# Altium schematic sheet Schem, Transadmittance Amp_rev_B.SchDoc
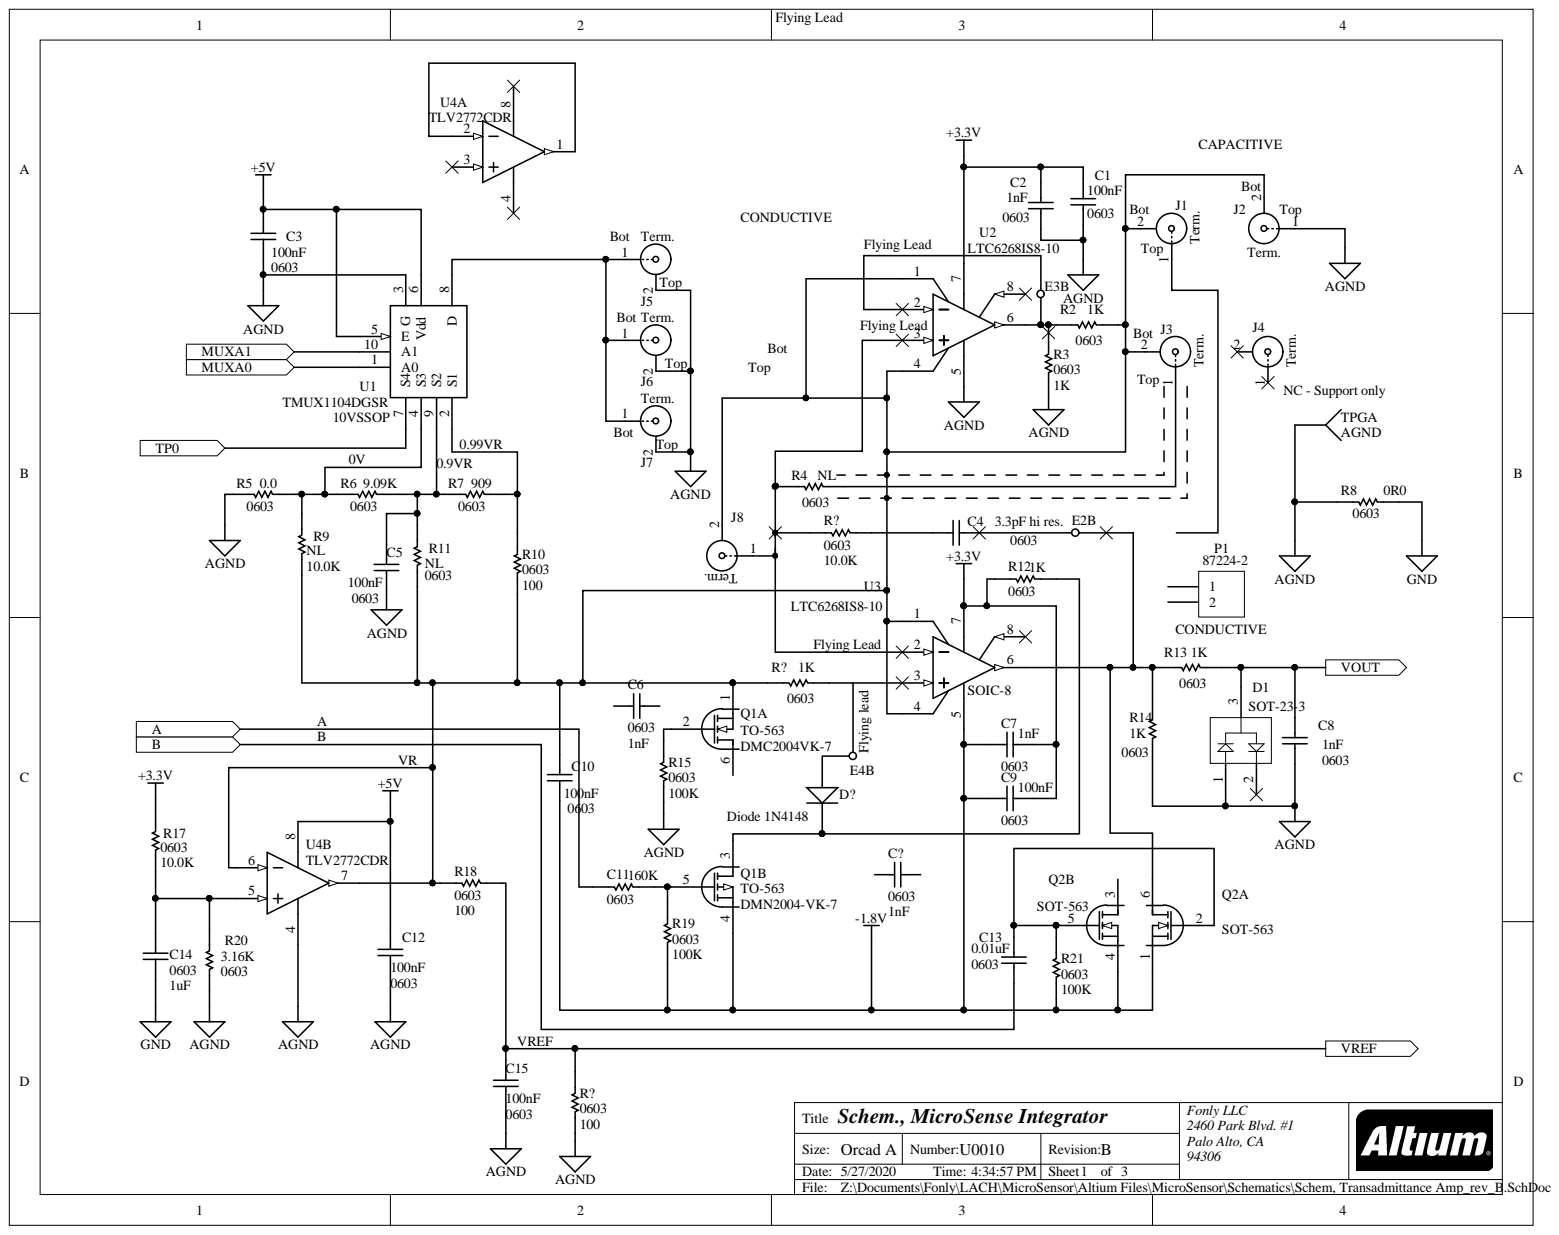
[diagram p0: Schem, Transadmittance Amp_rev_B.SchDoc]

## Parts
C1  0603  value 100nF  [1608[0603]]
C2  0603  value 1nF  [1608[0603]]
C3  0603  value 100nF  [1608[0603]]
C4  0603  value 3.3pF hi res.  [1608[0603]]
C5  0603  value 100nF  [1608[0603]]
C6  0603  value 1nF  [1608[0603]]
C7  0603  value 1nF  [1608[0603]]
C8  0603  value 1nF  [1608[0603]]
C9  0603  value 100nF  [1608[0603]]
C10  0603  value 100nF  [1608[0603]]
C11  0603  value 160K  [RESC1608L]
C12  0603  value 100nF  [1608[0603]]
C13  0603  value 0.01uF  [1608[0603]]
C14  0603  value 1uF  [1608[0603]]
C15  0603  value 100nF  [1608[0603]]
C?  0603  value 1nF  [1608[0603]]
D1  SOT-23-3  [DIOD-SOT23-3_V]
D?  Diode 1N4148  [DO-35]
E2  Socket  [SCKT-1.05H1]  (value not drawn)  (part B)
E3  Socket  [SCKT-1.05H1]  (value not drawn)  (part B)
E4  Socket  [SCKT-1.05H1]  (value not drawn)  (part B)
J1  Coax endpoint  [Coaxial Terminal]  (value not drawn)
J2  Coax endpoint  [Coaxial Terminal]  (value not drawn)
J3  Coax endpoint  [Coaxial Terminal]  (value not drawn)
J4  NC - Support only  [Coaxial Terminal]
J5  Coax endpoint  [Coaxial Terminal]  (value not drawn)
J6  Coax endpoint  [Coaxial Terminal]  (value not drawn)
J7  Coax endpoint  [Coaxial Terminal]  (value not drawn)
J8  Coax endpoint  [Coaxial Terminal]  (value not drawn)
P1  CONDUCTIVE  MFG_P/N: 87224-2  [HDR1X2]
Q1  TO-563  MFG_P/N: DMC2004VK-7  [SOT-563]  (parts A,B)
Q2  SOT-563  [SOT-563]  (parts A,B)
R2  0603  value 1K  [RESC1608L]
R3  0603  value 1K  [RESC1608L]
R4  0603  value NL  [RESC1608L]
R5  0603  value 0.0  [RESC1608L]
R6  0603  value 9.09K  [RESC1608L]
R7  0603  value 909  [RESC1608L]
R8  0603  value 0R0  [RESC1608L]
R9  NL  value 10.0K  [RESC1608L]
R10  0603  value 100  [RESC1608L]
R11  0603  value NL  [RESC1608L]
R12  0603  value 1K  [RESC1608L]
R13  0603  value 1K  [RESC1608L]
R14  0603  value 1K  [RESC1608L]
R15  0603  value 100K  [RESC1608L]
R17  0603  value 10.0K  [RESC1608L]
R18  0603  value 100  [RESC1608L]
R19  0603  value 100K  [RESC1608L]
R20  0603  value 3.16K  [RESC1608L]
R21  0603  value 100K  [RESC1608L]
R?  0603  value 100  [RESC1608L]
R?  0603  value 10.0K  [RESC1608L]
R?  0603  value 1K  [RESC1608L]
TPGA  AGND  [PIN1]
U1  10VSSOP  [10VSSOP]
U2  MFG_P/N: LTC6268IS8-10  [LTC6268-SO8]  (hidden comment: SOIC-8)
U3  SOIC-8  MFG_P/N: LTC6268IS8-10  [LTC6268-SO8]
U4  TLV2772CDR  [D0008A_N]  (parts A,B)

## Ports
A, B, MUXA0, MUXA1, TP0, VOUT, VREF

## Nets
+3.3V: C1.1 C2.1 C7.2 C9.2 R12.1 R17.1 U2.7 U3.7
+5V: C3.1 C12.1 U1.5(E) U1.6(Vdd) U4.8
-1.8V: C7.1 C9.1 C10.2 Q1.4 Q2.1 Q2.4 R19.2 R21.2 U3.5
AGND: C1.2 C2.2 C3.2 C5.2 C8.2 C12.2 C15.2 D1.1 J2.1 J5.2 J6.2 J7.2 R3.2 R5.2 R8.1 R14.2 R15.2 R20.2 R?.2 TPGA.1 U1.3(G) U2.5 U4.4
GND: C14.2 R8.2
0.9VR: C5.1 R6.1 R7.2 R11.2 U1.9(S2)
0.99VR: R7.1 R10.1 U1.2(S1)
0V: R5.1 R6.2 R9.2 U1.4(S3)
A: C11.1 ; port A
B: C13.2 ; port B
MUXA0: U1.1(A0) ; port MUXA0
MUXA1: U1.10(A1) ; port MUXA1
TP0: U1.7(S4) ; port TP0
VOUT: C8.1 D1.3 R13.1 ; port VOUT
VR: C10.1 J1.2 J2.2 J3.2 J8.2 Q1.1 R2.1 R9.1 R10.2 R11.1 R18.2 R?.2 U2.1 U2.4 U3.1 U3.4 U4.6 U4.7
VREF: C15.1 R18.1 R?.1 ; port VREF
NetC4_1: C4.1 R?.2
NetC11_2: C11.2 Q1.5 R19.1
NetC13_1: C13.1 Q2.2 Q2.5 R21.1
NetC14_1: C14.1 R17.2 R20.1 U4.5
NetC?_1: C?.1
NetD?_2: D?.2 Q1.3 R12.2
NetE2_1: E2.1 Q2.6 R13.2 R14.1 U3.6
NetE3_1: E3.1 R2.2 R3.1 U2.6
NetJ3_1: J3.1 R4.1
NetJ5_1: J5.1 J6.1 J7.1 U1.8(D)
NetJ8_1: J8.1 R4.2
NetQ1_2: Q1.2 R15.1
NetU4_1: U4.1 U4.2

Not connected: C4 2; C6 1 2; C? 2; D1 2; D? 1; E4 1; J1 1; J4 1 2; P1 1 2; Q1 6; Q2 3; U2 2 3 8; U3 2 3 8; U4 3

## Library data of the parts
C1 C3 C5 C9 C10 C12 C15 = Cap Semi: Capacitor Ceramic, SMD, 0603, 0.1uF, 16V, 10%; MFG: Samsung; MFG_P/N: CL10B104KO8NNWC; Vendor: DigiKey; Vendor P/N: 1276-1939-1-ND; Component Kind: Standard; Library Name: Miscellaneous Devices.SchLib; Signal Integrity: Cap Semi; Simulation: CAP
C2 C6-C8 C? = Cap Semi: Capacitor Ceramic, SMD, 0603, C0G, 1nF, 50V, 5%; MFG: Samsung; MFG_P/N: CL10C102JB8NFNC; Vendor: DigiKey; Vendor P/N: 1276-2170-1-ND; Component Kind: Standard; Library Name: Miscellaneous Devices.SchLib; Signal Integrity: Cap Semi; Simulation: CAP
  C6: Value: 1nF
C4 = Cap Semi: Cap., ceramic, ultra-lo ESR, 3.3pF 10^12 ohm; MFG: AVX; MFG_P/N: MK03V73R3BAT2A; Value: 3.3pF hi res.; Vendor: DigiKey; Vendor P/N: 478-6283-1-ND; Component Kind: Standard; Library Name: Miscellaneous Devices.SchLib; Signal Integrity: Cap Semi; Simulation: CAP
C11 R2-R4 R12-R14 R? = Res1: Resistor; MFG: Panasonic; MFG_P/N: ERJ-3EKF1001V; Vendor: DigiKey; Vendor P/N: P1.00KHCT-ND; Component Kind: Standard; Library Name: Miscellaneous Devices.SchLib; Signal Integrity: Res; Simulation: RESISTOR
C13 = Cap Semi: Capacitor Ceramic, SMD, X7R, 0603, .01uF, 16V, 10%; MFG: Kemet; MFG_P/N: C0603C103K4RACAUTO; Vendor: DigiKey; Vendor P/N: 399-12987-1-ND; Component Kind: Standard; Library Name: Miscellaneous Devices.SchLib; Signal Integrity: Cap Semi; Simulation: CAP
C14 = Cap Semi: Capacitor Ceramic, SMD, 0603, .01uF, 16V, 10%; MFG: Kemet; MFG_P/N: C0603C105M3RACTU; Vendor: DigiKey; Vendor P/N: 399-7377-1-ND; Component Kind: Standard; Library Name: Miscellaneous Devices.SchLib; Signal Integrity: Cap Semi; Simulation: CAP
D1 = CMP-1667-00006-1: Surface Mount Schottky Barrier Diode, -65 to 150 degC, 3-Pin SOT23, RoHS, Tape and Reel; Colour: Orange; MFG: Diodes Inc.; MFG_P/N: BAT54S-FDICT-ND; Vendor: DigiKey; Component Kind: Standard; ComponentLink1Description: Manufacturer URL; ComponentLink1URL: http://www.diodes.com/; ComponentLink2Description: Datasheet; ComponentLink2URL: http://www.diodes.com/_files/datasheets/ds11005.pdf; Contact Material: Bronze; Contact Plating: Tin; DatasheetVersion: Rev. 31-2, 10/2013; Diameter: 2.54 mm; Height: 4.57 mm; Length: 7.62 mm; Library Name: Altium Content Vault; Mount: Through Hole; PackageDescription: 3-Pin Small Outline Transistor, Body 2.9 x 1.3 mm, Pitch 0.95 mm; Package Quantity: 10; PackageReference: SOT23-3; Packaging: Bulk; Pins: 1; REACH SVHC: No SVHC; RoHSCompliant: true; Vendor P/N: BAT54S-FDICT-ND
D? = Diode 1N4148: High Conductance Fast Diode; Code_JEDEC: DO-204-AH; Component Kind: Standard; ComponentLink1Description: Manufacturer Link; ComponentLink1URL: http://www.fairchildsemi.com/; ComponentLink2Description: Datasheet; ComponentLink2URL: http://www.fairchildsemi.com/ds/1N%2F1N4148.pdf; DatasheetVersion: 1997; LatestRevisionDate: 17-Jul-2002; LatestRevisionNote: Re-released for DXP Platform.; Library Name: Miscellaneous Devices.SchLib; PackageDescription: Diode, Axial; Body 3.8 x 1.9 mm (LxDia. max), Lead Dia. 0.53 mm (max); PackageReference: DO-35; Published: 8-Jun-2000; Signal Integrity: 1N4148; Simulation: 1N4148; Publisher: Altium Limited
J1-J8 = Coaxial Term: Coaxial pattern for 375mil outer spacers, connected (M-F) standoff ctr.; Component Kind: Standard; Library Name: Current Miscellaneous Connectors.SchLib
P1 = Header 2: Header, 2-Pin, M, 0.1"; MFG: TE AMP; Vendor: DigiKey; Vendor P/N: A26543-ND; Component Kind: Standard; LatestRevisionDate: 17-Jul-2002; LatestRevisionNote: Re-released for DXP Platform.; Library Name: Current Miscellaneous Connectors.SchLib; Signal Integrity: Connector; Publisher: Altium Limited
Q1 = DMC2004: Dual Complementary MOSFET Transistor; MFG: Diodes Inc.; Vendor: DigiKey; Vendor P/N: DMC2004VKDICT-ND; Component Kind: Standard; Library Name: Apigy_Lockitron.SCHLIB
Q2 = Dual NMOS DMN2004: Dual NMOS transistor, SOT-563, 20V 540ma; MFG: Diodes Inc.; Vendor: DigiKey; Component Kind: Standard; Library Name: Miscellaneous Devices.SchLib
R5-R7 = Res1: Resistor; MFG: Panasonic; MFG_P/N: ERJ-3EKF9102V; Vendor: DigiKey; Vendor2: Mouser; Vendor2 P/N: 667-ERJ-3EKF9092V; Vendor P/N: P90.9KHCT-ND; Component Kind: Standard; Library Name: Miscellaneous Devices.SchLib; Signal Integrity: Res; Simulation: RESISTOR
R8 = Res1: Resistor; MFG: Panasonic; MFG_P/N: ERJ-3GEY0R00V; Vendor: DigiKey; Vendor P/N: ERJ-3EKF49R9V; Component Kind: Standard; Library Name: Miscellaneous Devices.SchLib; Signal Integrity: Res; Simulation: RESISTOR
R9 R11 R17 R? = Res1: Resistor; MFG: Panasonic; MFG_P/N: ERJ-3EKF1002V; Vendor: DigiKey; Vendor P/N: P10.0KHCT-ND; Component Kind: Standard; Library Name: Miscellaneous Devices.SchLib; Signal Integrity: Res; Simulation: RESISTOR
R10 R? = Res1: Resistor; MFG: Panasonic; MFG_P/N: ERJ-3EKF9091V; Vendor: DigiKey; Vendor P/N: P9.09KHCT-ND; Component Kind: Standard; Library Name: Miscellaneous Devices.SchLib; Signal Integrity: Res; Simulation: RESISTOR
R15 R19 R21 = Res1: Resistor; MFG: Panasonic; MFG_P/N: ERJ-3EKF1003V; Vendor: DigiKey; Vendor P/N: P100KHCT-ND; Component Kind: Standard; Library Name: Miscellaneous Devices.SchLib; Signal Integrity: Res; Simulation: RESISTOR
R18 = Res1: Resistor; MFG: Panasonic; MFG_P/N: ERJ-3EKF1000V; Vendor: DigiKey; Vendor P/N: P100HCT-ND; Component Kind: Standard; Library Name: Miscellaneous Devices.SchLib; Signal Integrity: Res; Simulation: RESISTOR
R20 = Res1: Resistor; MFG: Panasonic; MFG_P/N: ERJ-3EKF2002V; Vendor: DigiKey; Vendor P/N: P20.0KHCT-ND; Component Kind: Standard; Library Name: Miscellaneous Devices.SchLib; Signal Integrity: Res; Simulation: RESISTOR
TPGA = Socket: Component Kind: Standard; Description: Socket; LatestRevisionDate: 17-Jul-2002; LatestRevisionNote: Re-released for DXP Platform.; Library Name: Current Miscellaneous Connectors.SchLib; Signal Integrity: Connector; Publisher: Altium Limited
U1 = TMUX1104DGSR: Analog Mux 4:1 low leakage; MFG: TI; Vendor: DigiKey; Vendor P/N: 296-53437-1-ND; Component Kind: Standard; Library Name: TI Logic Switch.SchLib; MFG_P/N: TMUX1104DGSR
U2 U3 = LTC6268-10: Op Amp, low input current; MFG: Analog Devices; Vendor: DigiKey; Vendor P/N: LTC6268IS8-10#PBF-ND; Component Kind: Standard; Library Name: LT Operational Amplifier.SchLib
U4 = CMP-0272-03014-3: Dual High-Slew-Rate Rail-to-Rail Output Operational Amplifier, 2.5 to 6 V, 0 to 70 degC, 8-pin SOIC (D8), Green (RoHS & no Sb/Br); MFG: TI; Vendor: DigiKey; Vendor P/N: 296-10677-1-ND; Component Kind: Standard; ComponentLink1Description: Manufacturer URL; ComponentLink1URL: http://www.ti.com/; ComponentLink2Description: Datasheet; ComponentLink2URL: http://www.ti.com/lit/ds/symlink/tlv2772.pdf; ComponentLink3Description: Package Specification; ComponentLink3URL: http://www.ti.com/litv/pdf/msoi002j; Library Name: Altium Content Vault; MFG_P/N: TLV2772CDR
E2-E4 = Socket: Component Kind: Standard; Description: Socket; LatestRevisionDate: 17-Jul-2002; LatestRevisionNote: Re-released for DXP Platform.; Library Name: Current Miscellaneous Connectors.SchLib; Signal Integrity: Connector; Publisher: Altium Limited
